# Altium schematic sheet CPU.SchDoc
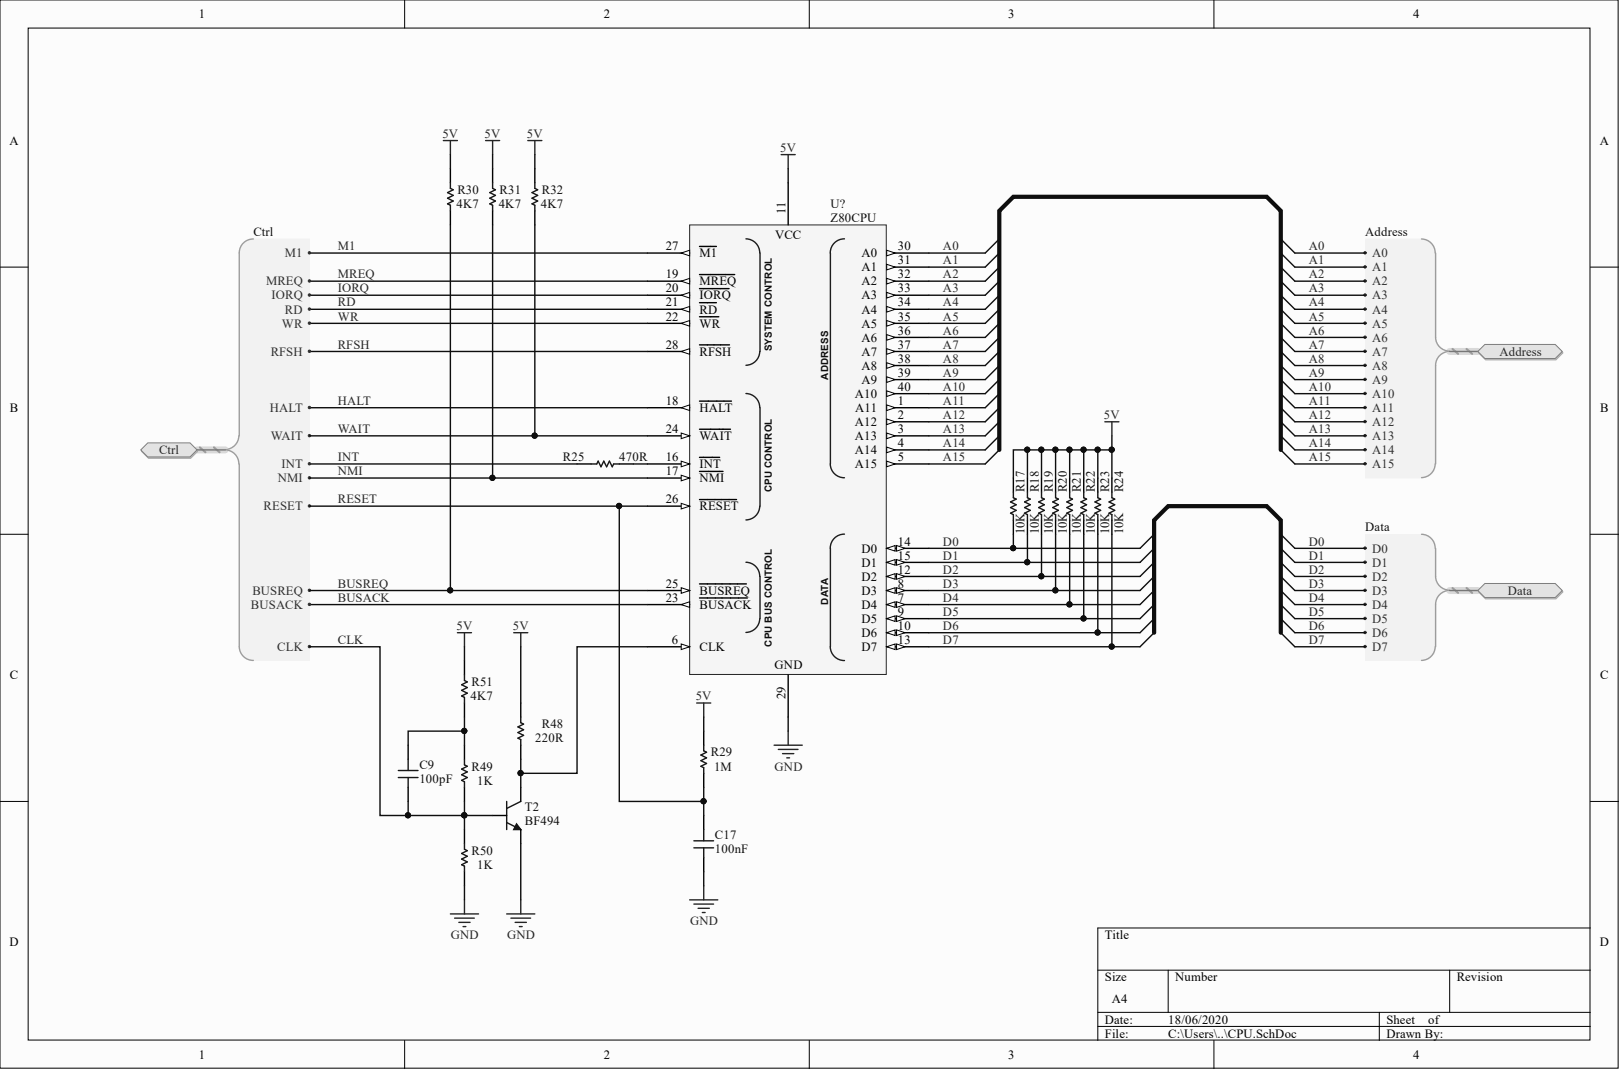
[diagram p0: CPU.SchDoc]

## Parts
C9  100pF  [DiskCapacitor]
C17  100nF  [DiskCapacitor]
R17  10K  [CR25]
R18  10K  [CR25]
R19  10K  [CR25]
R20  10K  [CR25]
R21  10K  [CR25]
R22  10K  [CR25]
R23  10K  [CR25]
R24  10K  [CR25]
R25  470R  [CR25]
R29  1M  [CR25]
R30  4K7  [CR25]
R31  4K7  [CR25]
R32  4K7  [CR25]
R48  220R  [CR25]
R49  1K  [CR25]
R50  1K  [CR25]
R51  4K7  [CR25]
T2  BF494  [TO-92]
U?  Z80CPU  [Z84C00xxPEG]

## Ports
ADDRESS, ADDRESS.A0, ADDRESS.A1, ADDRESS.A2, ADDRESS.A3, ADDRESS.A4, ADDRESS.A5, ADDRESS.A6, ADDRESS.A7, ADDRESS.A8, ADDRESS.A9, ADDRESS.A10, ADDRESS.A11, ADDRESS.A12, ADDRESS.A13, ADDRESS.A14, ADDRESS.A15, CTRL, CTRL.BUSACK, CTRL.BUSREQ, CTRL.CLK, CTRL.HALT, CTRL.INT, CTRL.IORQ, CTRL.M1, CTRL.MREQ, CTRL.NMI, CTRL.RD, CTRL.RESET, CTRL.RFSH, CTRL.WAIT, CTRL.WR, DATA, DATA.D0, DATA.D1, DATA.D2, DATA.D3, DATA.D4, DATA.D5, DATA.D6, DATA.D7

## Nets
5V: R17.2 R18.2 R19.2 R20.2 R21.2 R22.2 R23.2 R24.2 R29.2 R30.2 R31.2 R32.2 R48.2 R51.2 U?.11
GND: C17.1 R50.1 T2.2 U?.29
A0: U?.30(A0) ; port ADDRESS
A1: U?.31(A1) ; port ADDRESS
A2: U?.32(A2) ; port ADDRESS
A3: U?.33(A3) ; port ADDRESS
A4: U?.34(A4) ; port ADDRESS
A5: U?.35(A5) ; port ADDRESS
A6: U?.36(A6) ; port ADDRESS
A7: U?.37(A7) ; port ADDRESS
A8: U?.38(A8) ; port ADDRESS
A9: U?.39(A9) ; port ADDRESS
A10: U?.40(A10) ; port ADDRESS
A11: U?.1(A11) ; port ADDRESS
A12: U?.2(A12) ; port ADDRESS
A13: U?.3(A13) ; port ADDRESS
A14: U?.4(A14) ; port ADDRESS
A15: U?.5(A15) ; port ADDRESS
BUSACK: U?.23(BUSACK) ; port CTRL
BUSREQ: R30.1 U?.25(BUSREQ) ; port CTRL
CLK: C9.1 R49.1 R50.2 T2.1 ; port CTRL
D0: R17.1 U?.14(D0) ; port DATA
D1: R18.1 U?.15(D1) ; port DATA
D2: R19.1 U?.12(D2) ; port DATA
D3: R20.1 U?.8(D3) ; port DATA
D4: R21.1 U?.7(D4) ; port DATA
D5: R22.1 U?.9(D5) ; port DATA
D6: R23.1 U?.10(D6) ; port DATA
D7: R24.1 U?.13(D7) ; port DATA
HALT: U?.18(HALT) ; port CTRL
INT: R25.1 ; port CTRL
IORQ: U?.20(IORQ) ; port CTRL
M1: U?.27(M1) ; port CTRL
MREQ: U?.19(MREQ) ; port CTRL
NMI: R31.1 U?.17(NMI) ; port CTRL
RD: U?.21(RD) ; port CTRL
RESET: C17.2 R29.1 U?.26(RESET) ; port CTRL
RFSH: U?.28(RFSH) ; port CTRL
WAIT: R32.1 U?.24(WAIT) ; port CTRL
WR: U?.22(WR) ; port CTRL
NetC9_2: C9.2 R49.2 R51.1
NetR25_2: R25.2 U?.16(INT)
NetR48_1: R48.1 T2.3 U?.6(CLK)
N00035:
N00036:

## Library data of the parts
C9 C17 = Capacitor: Component Kind: Standard; Library Name: Basic.IntLib
  C9: Description: 100pF
  C17: Description: 100nF
R17-R25 R29-R32 R48-R51 = Resistor: Component Kind: Standard; Library Name: Basic.IntLib
  R17-R24: Description: 10K
  R25: Description: 470R
  R29: Description: 1M
  R30-R32 R51: Description: 4K7
  R48: Description: 220R
  R49 R50: Description: 1K
T2 = BF494: NPN Medium Frequency Transistors; Component Kind: Standard; Library Name: Basic.IntLib
U? = Z84C00xxPEG: Z80 - CPU; Component Kind: Standard; Library Name: Zilog.IntLib
